# Altium schematic sheet Boost Converter.SchDoc("Boost Converter")
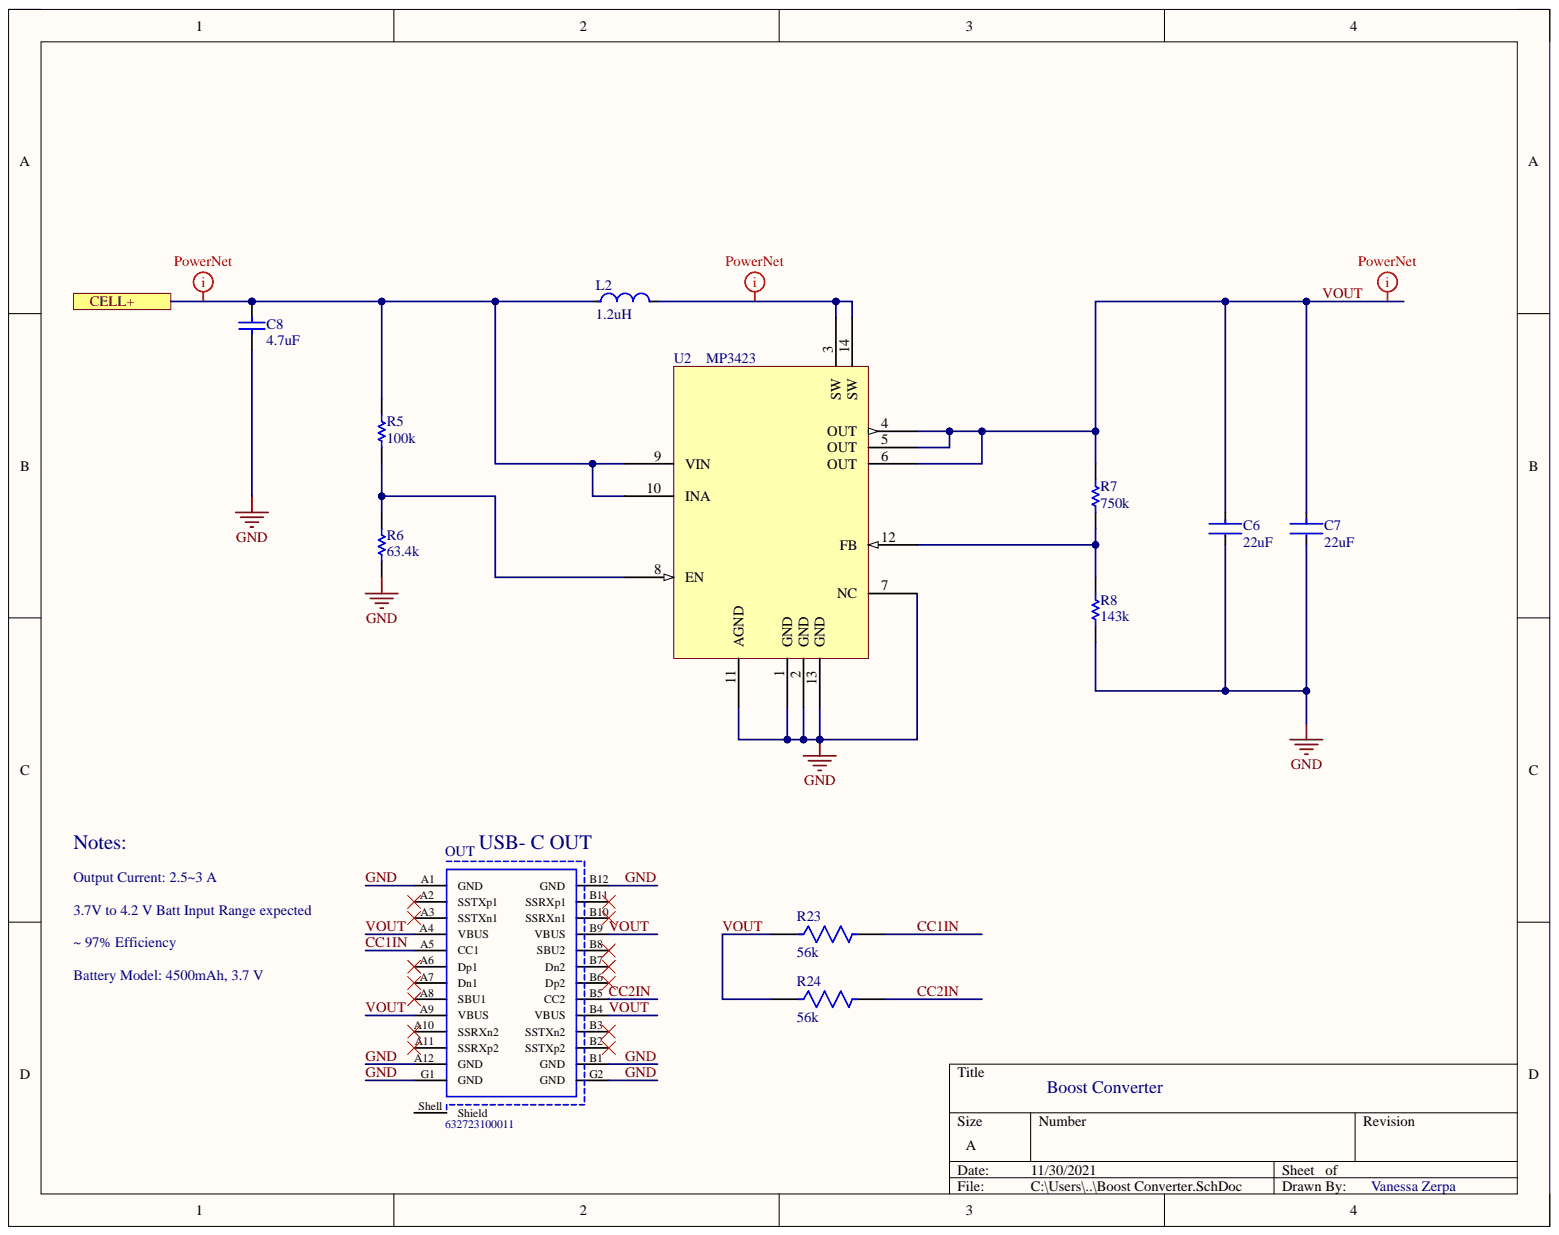
[diagram p0: Boost Converter.SchDoc]

## Parts
C6  22uF  [FP-C2012-125-MFG]
C7  22uF  [FP-C2012-125-MFG]
C8  4.7uF  [CAPC2013X145X50ML20T25]
L2  1.2uH  [FP-LQW21HN_00-MFG]
OUT  632723100011  [632723100011]
R5  100k  [RESC2013X60X35ML10T20]
R6  63.4k  [RESC2013X50X30LL20T20]
R7  750k  [RESC2013X50X30LL20T20]
R8  143k  [RESC2013X70X40LL20T20]
R23  56k  [FP-CRCW0805-e3-IPC_B]
R24  56k  [FP-CRCW0805-e3-IPC_B]
U2  MP3423  [MP3422GGZ]

## Ports
CELL+

## Nets
CC1IN: OUT.A5(CC1) R23.2
CC2IN: OUT.B5(CC2) R24.2
CELL+: C8.2 L2.1 R5.2 U2.9(VIN) U2.10(INA) ; port CELL+
GND: C6.2 C7.2 C8.1 OUT.A1(GND) OUT.A12(GND) OUT.B1(GND) OUT.B12(GND) OUT.G1(GND) OUT.G2(GND) R6.1 R8.1 U2.1(GND) U2.2(GND) U2.7(NC) U2.11(AGND) U2.13(GND)
VOUT: C6.1 C7.1 OUT.A4(VBUS) OUT.A9(VBUS) OUT.B4(VBUS) OUT.B9(VBUS) R7.2 R23.1 R24.1 U2.4(OUT) U2.5(OUT) U2.6(OUT)
NetL2_2: L2.2 U2.3(SW) U2.14(SW)
NetR5_1: R5.1 R6.2 U2.8(EN)
NetR7_1: R7.1 R8.2 U2.12(FB)

Not connected: OUT A2(SSTXp1) A3(SSTXn1) A6(Dp1) A7(Dn1) A8(SBU1) A10(SSRXn2) A11(SSRXp2) B2(SSTXp2) B3(SSTXn2) B6(Dp2) B7(Dn2) B8(SBU2) B10(SSRXn1) B11(SSRXp1) Shell(Shield)

## Library data of the parts
C6 C7 = CMP-2000-06037-2: CAP CER 22UF 16V X5R 0805; Manufacturer: TDK; Manufacturer Part Number: C2012X5R1C226K125AC; Material: Ceramic; Temperature Coefficient: 15%; Tolerance: 10%; Automotive: No; Capacitance: 22uF; Category: Cap; Component Kind: Standard; ComponentLink2Description: Datasheet; ComponentLink2URL: https://product.tdk.com/info/en/catalog/datasheets/mlcc_commercial_general_en.pdf; Device Class L1: Passive Components; Device Class L2: Capacitors; Device Class L3: Ceramic Capacitors; Digikey Description: CAP CER 22UF 16V X5R 0805; Footprint Url: https://product.tdk.com/info/en/catalog/spec/mlccspec_commercial_general_midvoltage_en.pdf; Height: 1.45mm; Ipc Land Pattern Name: CAPC200125X125; Lead Free: Yes; Library Name: Altium Content Vault; Octopart: https://octopart.com/c2012x5r1c226k125ac-tdk-25947713; Package: C2012-125; RoHS: TRUE; Temperature: -55°C to +85°C; Temperature Characteristic: X5R; Temperature Range High: +85°C; Temperature Range Low: -55°C; Value: 22uF; Voltage: 16V; Voltage Rating: 16V
C8 = CMP-2007-01007-1: Manufacturer: Kemet; Part Number: C0805C475K8PACTU; Component Kind: Standard; Library Name: Altium Content Vault
L2 = CMP-06042-002176-1: Wire Wound Ferrite type RF Inuctor 1.2uH ±5% 100mA 3.12Ω 0805 (2012); DC Resistance (DCR): 3.12R; Q Factor: 35; Self Resonant Frequency: 400MHz; Shielding: Unshielded; Test Frequency: 10MHz; Case Code (Imperial): 0805; Case Code (Metric): 2012; Case/Package: 0805; Component Kind: Standard; Core Material: Ferrite; Current Rating: 100mA; DC Current: 100mA; Depth: 1.4mm; Height: 0.9mm; Height - Seated (Max): 0.039inch; Inductance: 1.2uH; Lead Free: Lead Free; Length: 2mm; Library Name: Altium Content Vault; Max DC Current: 100mA; Max Operating Temperature: 85°C; Military Standard: Not; Min Operating Temperature: -55°C; Mount: Surface Mount; Package Quantity: 3000; Packaging: Tape and Reel; Radiation Hardening: No; Resistor Type: Wirewound; Termination: SMD/SMT; Tolerance: 5%; Weight: 0.000282oz; Width: 1.5mm
OUT = CMP-1502-03213-1: USB 3.1 Type C Receptacle WR-COM, Horizontal, SMT / THT; Manufacturer: Wurth Elektronik; Contact Resistance: 30mOhm; Rated Current: 5A; Working Voltage: 20VDC; Component Kind: Standard; Connector Type: USB3.1TypeC; Datasheet URL: https://katalog.we-online.de/em/datasheet/632723x00011%282%29.pdf; Gender: Receptacle; Library Name: Altium Content Vault; Manufacturer URL: http://www.we-online.de; Mounting Technology: SMT/THT; Operating Temperature: -40to105degC; Orientation: Horizontal; Package Reference: 632723100011; Packaging: TapeandReel; Part Number: 632723100011; Publisher: WurthElektronik
R5 = CMP-2100-03668-1: Chip Resistor, 100 KOhm, +/- 1%, 0.125 W, -55 to 155 degC, 0805 (2012 Metric), RoHS, Tape and Reel; Manufacturer: Yageo; Max Power Dissipation: 125mW; Temperature Coefficient: 100ppm/degreesC; Voltage Rating: 150V; Case Code (Imperial): 0805; Case Code (Metric): 2012; Case/Package: 0805; Component Kind: Standard; Composition: ThickFilm; Datasheet URL: http://www.yageo.com/NewPortal/yageodocoutput?fileName=/pdf/R-Chip/PYu-RC_Group_51_RoHS_L_5.pdf; Datasheet Version: Rev. 5, 10/2016; Depth: 1.25mm; Height: 0.024inch; Lead Free: LeadFree; Lead Pitch: 0.4mm; Length: 2mm; Library Name: Altium Content Vault; Manufacturer URL: http://www.yageo.com/; Max Operating Temperature: 155degC; Military Standard: Not; Min Operating Temperature: -55degC; Number of Pins: 2; Number of Terminations: 2; Package Description: 2-Pin Surface Mounted Device, Body 2 x 1.25 mm; Package Quantity: 1; Package Reference: 0805; Packaging: TapeandReel; Power Rating: 125mW; REACH SVHC: Unknown; Resistance: 100KOhm; RoHSCompliant: true; Termination: SMD/SMT; Tolerance: 1%; Voltage Rating (DC): 150V; Width: 0.049inch
R6 = CMP-2001-04057-1: Manufacturer: Vishay Dale; Part Number: CRCW080563K4FKEA; Component Kind: Standard; Library Name: Altium Content Vault
R7 = CMP-2001-04102-1: Manufacturer: Vishay Dale; Part Number: CRCW0805750KFKEA; Component Kind: Standard; Library Name: Altium Content Vault
R8 = CMP-2001-04433-1: Manufacturer: Panasonic; Part Number: ERJ-6ENF1433V; Component Kind: Standard; Library Name: Altium Content Vault
R23 R24 = CMP-2001-04015-2: RES Thick Film, 56kΩ, 1%, 0.125W, 100ppm/°C, 0805; Max Power Dissipation: 125mW; Resistance: 56kR; Voltage Rating: 150V; Case Code (Imperial): 0805; Case Code (Metric): 2012; Case/Package: 0805; Component Kind: Standard; Composition: Thick Film; Contact Plating: Tin; Depth: 1.25mm; Features: Automotive AEC-Q200; Height: 0.45mm; Lead Free: Lead Free; Length: 2mm; Library Name: Altium Content Vault; Max Operating Temperature: 155°C; Military Standard: Not; Min Operating Temperature: -55°C; Mount: Surface Mount; Number of Pins: 2; Number of Terminations: 2; Package Quantity: 10; Packaging: Tape and Reel; Power Rating: 125mW; Radiation Hardening: No; Temperature Coefficient: 100ppm/°C; Termination: SMD/SMT; Tolerance: 1%; Voltage Rating (DC): 150V; Weight: 0.000194oz; Width: 1.25mm
U2 = MP3423: Component Kind: Standard; Library Name: BoostConverter.SchLib
